# Altium schematic sheet Top Level.SchDoc
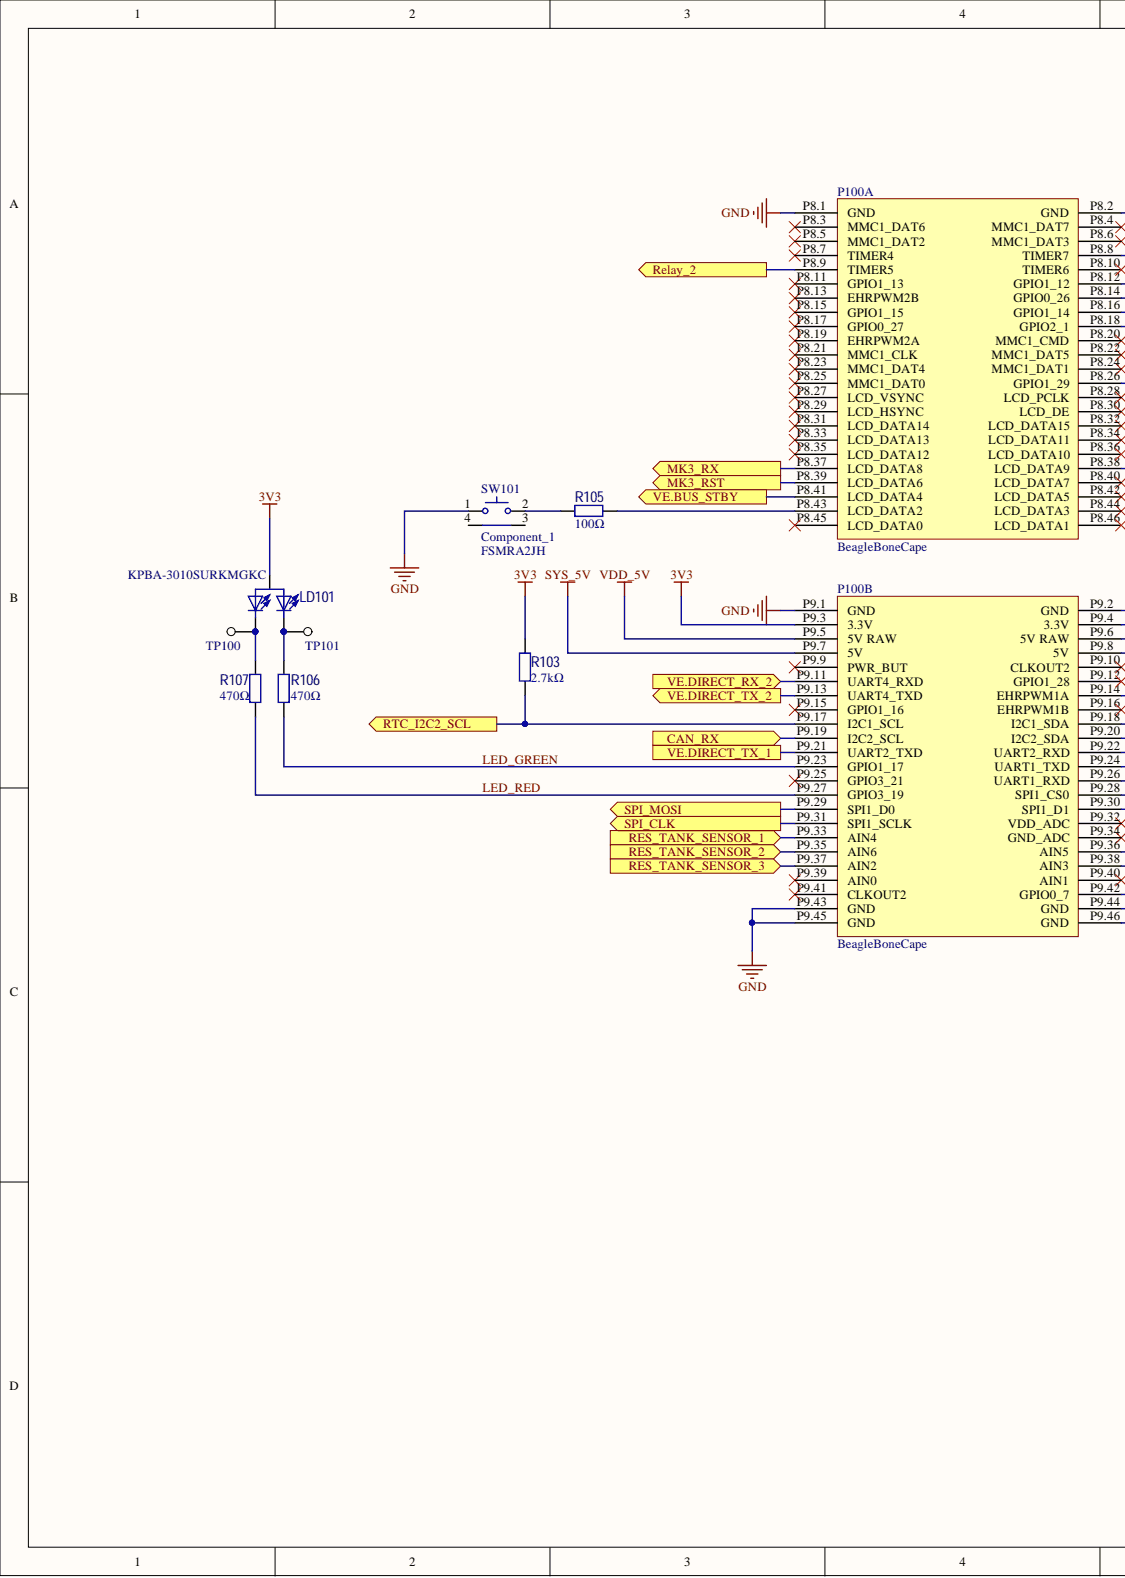
[diagram p0: Top Level.SchDoc, left]
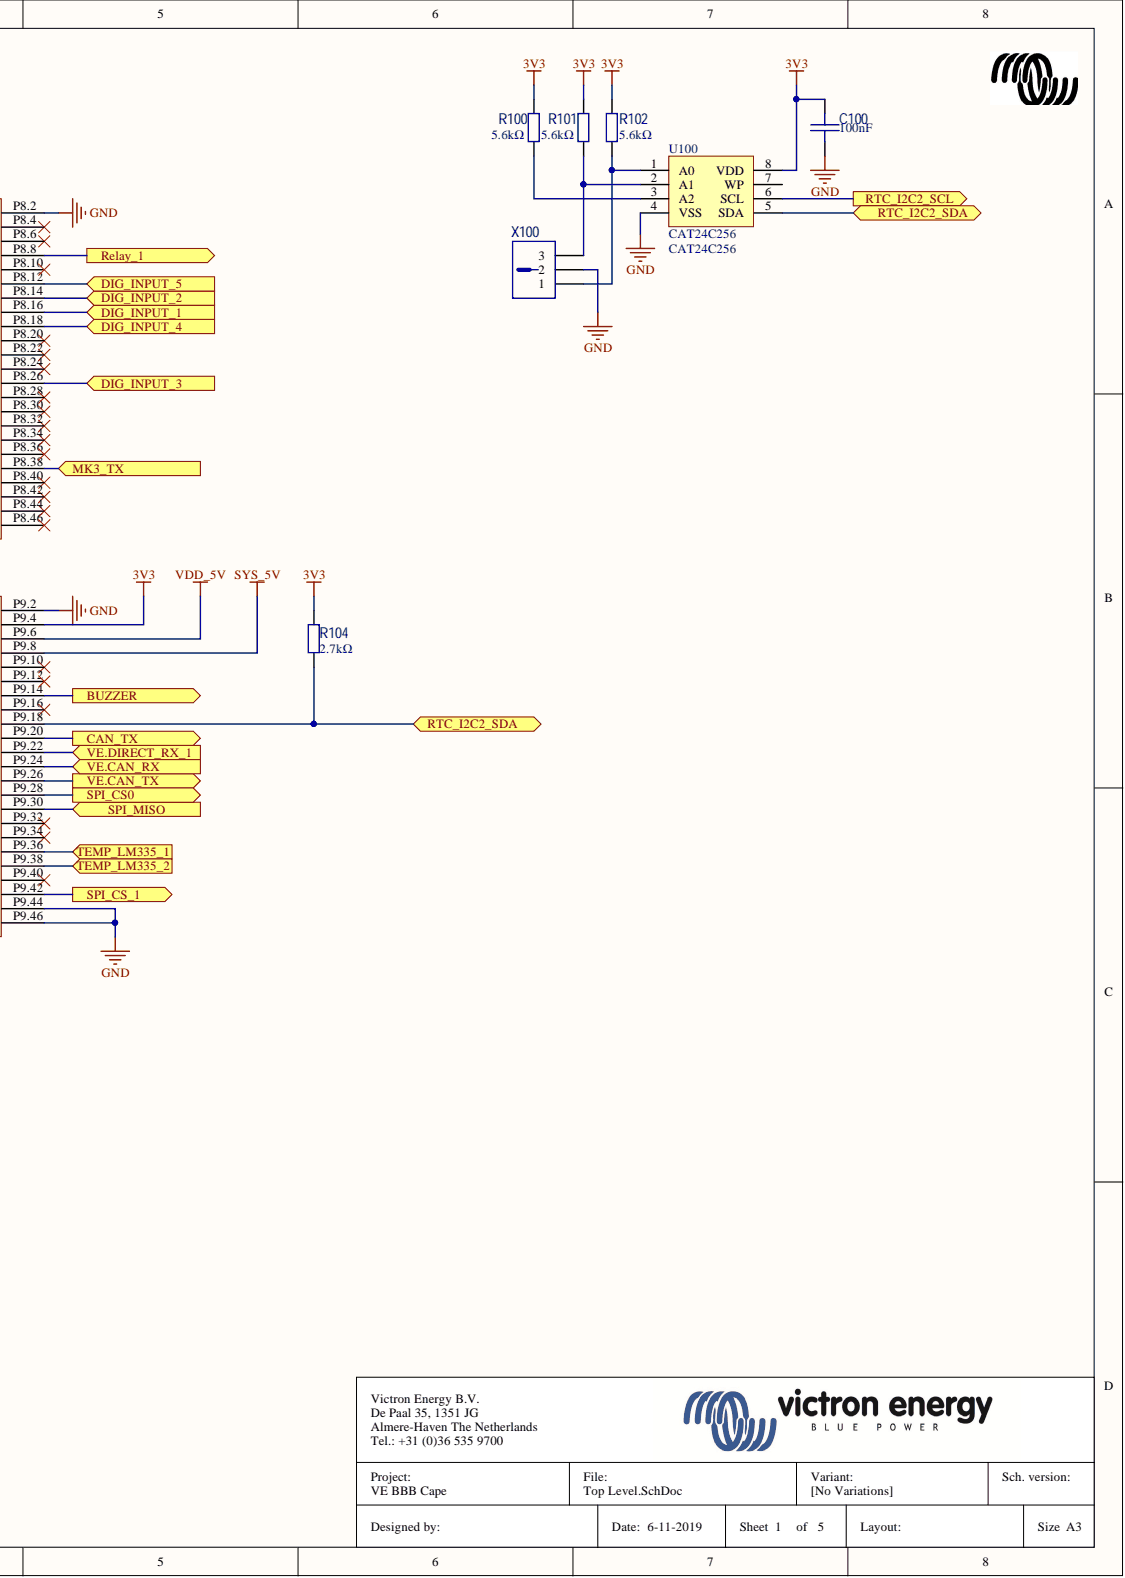
[diagram p1: Top Level.SchDoc, right]

## Parts
C100  value 100nF  [C0805_R]  (hidden comment: 1035)
LD101  value KPBA-3010SURKMGKC  MAN_PARTNUMBER: KPBA-3010SURKMGKC  [SMD side LED 2color KPBA]  (hidden comment: 101623)
P100  BeagleBoneCape  [BeagleBoneCape]  (parts A,B)
R100  value 5.6kΩ  [R0805_R]  (hidden comment: 390)
R101  value 5.6kΩ  [R0805_R]  (hidden comment: 390)
R102  value 5.6kΩ  [R0805_R]  (hidden comment: 390)
R103  value 2.7kΩ  [R0805_R]  (hidden comment: 382)
R104  value 2.7kΩ  [R0805_R]  (hidden comment: 382)
R105  value 100Ω  [R0805_R]  (hidden comment: 348)
R106  value 470Ω  [R0805_R]  (hidden comment: 364)
R107  value 470Ω  [R0805_R]  (hidden comment: 364)
SW101  Component_1  value FSMRA2JH  [FSMRA2JH]
TP100  CN1  [testpad_round_1mm]  (value not drawn)  (no BOM)
TP101  CN1  [testpad_round_1mm]  (value not drawn)  (no BOM)
U100  CAT24C256  [SOIC-8]
X100  100129  [3M]  (value not drawn)

## Ports
BUZZER, CAN_RX, CAN_TX, DIG_INPUT_1, DIG_INPUT_2, DIG_INPUT_3, DIG_INPUT_4, DIG_INPUT_5, MK3_RST, MK3_RX, MK3_TX, Relay_1, Relay_2, RES_TANK_SENSOR_1, RES_TANK_SENSOR_2, RES_TANK_SENSOR_3, RTC_I2C2_SCL, RTC_I2C2_SDA, SPI_CLK, SPI_CS0, SPI_CS_1, SPI_MISO, SPI_MOSI, TEMP_LM335_1, TEMP_LM335_2, VE.BUS_STBY, VE.CAN_RX, VE.CAN_TX, VE.DIRECT_RX_1, VE.DIRECT_RX_2, VE.DIRECT_TX_1, VE.DIRECT_TX_2

## Nets
3V3: C100.1 LD101.1 P100.P9.3(3.3V) P100.P9.4(3.3V) R100.1 R101.1 R102.1 R103.1 R104.1 U100.8(VDD)
GND: C100.2 P100.P8.1(GND) P100.P8.2(GND) P100.P9.1(GND) P100.P9.2(GND) P100.P9.43(GND) P100.P9.44(GND) P100.P9.45(GND) P100.P9.46(GND) SW101.1 U100.4(VSS) X100.2
SYS_5V: P100.P9.7(5V) P100.P9.8(5V)
VDD_5V: P100.P9.5(5V_RAW) P100.P9.6(5V_RAW)
BUZZER: P100.P9.14(EHRPWM1A) ; port BUZZER
CAN_RX: P100.P9.19(I2C2_SCL) ; port CAN_RX
CAN_TX: P100.P9.20(I2C2_SDA) ; port CAN_TX
DIG_INPUT_1: P100.P8.16(GPIO1_14) ; port DIG_INPUT_1
DIG_INPUT_2: P100.P8.14(GPIO0_26) ; port DIG_INPUT_2
DIG_INPUT_3: P100.P8.26(GPIO1_29) ; port DIG_INPUT_3
DIG_INPUT_4: P100.P8.18(GPIO2_1) ; port DIG_INPUT_4
DIG_INPUT_5: P100.P8.12(GPIO1_12) ; port DIG_INPUT_5
LED_GREEN: P100.P9.23(GPIO1_17) R106.2
LED_RED: P100.P9.27(GPIO3_19) R107.2
MK3_RST: P100.P8.39(LCD_DATA6) ; port MK3_RST
MK3_RX: P100.P8.37(LCD_DATA8) ; port MK3_RX
MK3_TX: P100.P8.38(LCD_DATA9) ; port MK3_TX
Relay_1: P100.P8.8(TIMER7) ; port Relay_1
Relay_2: P100.P8.9(TIMER5) ; port Relay_2
RES_TANK_SENSOR_1: P100.P9.33(AIN4) ; port RES_TANK_SENSOR_1
RES_TANK_SENSOR_2: P100.P9.35(AIN6) ; port RES_TANK_SENSOR_2
RES_TANK_SENSOR_3: P100.P9.37(AIN2) ; port RES_TANK_SENSOR_3
RTC_I2C2_SCL: P100.P9.17(I2C1_SCL) R103.2 U100.6(SCL) ; port RTC_I2C2_SCL
RTC_I2C2_SDA: P100.P9.18(I2C1_SDA) R104.2 U100.5(SDA) ; port RTC_I2C2_SDA
SPI_CLK: P100.P9.31(SPI1_SCLK) ; port SPI_CLK
SPI_CS0: P100.P9.28(SPI1_CS0) ; port SPI_CS0
SPI_CS_1: P100.P9.42(GPIO0_7) ; port SPI_CS_1
SPI_MISO: P100.P9.30(SPI1_D1) ; port SPI_MISO
SPI_MOSI: P100.P9.29(SPI1_D0) ; port SPI_MOSI
TEMP_LM335_1: P100.P9.36(AIN5) ; port TEMP_LM335_1
TEMP_LM335_2: P100.P9.38(AIN3) ; port TEMP_LM335_2
VE.BUS_STBY: P100.P8.41(LCD_DATA4) ; port VE.BUS_STBY
VE.CAN_RX: P100.P9.24(UART1_TXD) ; port VE.CAN_RX
VE.CAN_TX: P100.P9.26(UART1_RXD) ; port VE.CAN_TX
VE.DIRECT_RX_1: P100.P9.22(UART2_RXD) ; port VE.DIRECT_RX_1
VE.DIRECT_RX_2: P100.P9.11(UART4_RXD) ; port VE.DIRECT_RX_2
VE.DIRECT_TX_1: P100.P9.21(UART2_TXD) ; port VE.DIRECT_TX_1
VE.DIRECT_TX_2: P100.P9.13(UART4_TXD) ; port VE.DIRECT_TX_2
NetLD101_2: LD101.2 R107.1 TP100.1
NetLD101_3: LD101.3 R106.1 TP101.1
NetP100_P8.3: P100.P8.3(MMC1_DAT6) P100.P8.30(LCD_DE)
NetP100_P8.4: P100.P8.4(MMC1_DAT7) P100.P8.40(LCD_DATA7)
NetP100_P8.43: P100.P8.43(LCD_DATA2) R105.2
NetR100_2: R100.2 U100.3(A2)
NetR101_2: R101.2 U100.2(A1) X100.3
NetR102_2: R102.2 U100.1(A0) X100.1
NetR105_1: R105.1 SW101.2

Not connected: P100 P8.5(MMC1_DAT2) P8.6(MMC1_DAT3) P8.7(TIMER4) P8.10(TIMER6) P8.11(GPIO1_13) P8.13(EHRPWM2B) P8.15(GPIO1_15) P8.17(GPIO0_27) P8.19(EHRPWM2A) P8.20(MMC1_CMD) P8.21(MMC1_CLK) P8.22(MMC1_DAT5) P8.23(MMC1_DAT4) P8.24(MMC1_DAT1) P8.25(MMC1_DAT0) P8.27(LCD_VSYNC) P8.28(LCD_PCLK) P8.29(LCD_HSYNC) P8.31(LCD_DATA14) P8.32(LCD_DATA15) P8.33(LCD_DATA13) P8.34(LCD_DATA11) P8.35(LCD_DATA12) P8.36(LCD_DATA10) P8.42(LCD_DATA5) P8.44(LCD_DATA3) P8.45(LCD_DATA0) P8.46(LCD_DATA1) P9.9(PWR_BUT) P9.10(CLKOUT2) P9.12(GPIO1_28) P9.15(GPIO1_16) P9.16(EHRPWM1B) P9.25(GPIO3_21) P9.32(VDD_ADC) P9.34(GND_ADC) P9.39(AIN0) P9.40(AIN1) P9.41(CLKOUT2); SW101 3 4; U100 7(WP)

## Library data of the parts
C100 = 1035: Cap, SMD 0805, ceramic, X7R, 100nF, 50V, 10%, Yageo; INDIC_PARTNO: CSE100NF0805YAGY; MAN_PARTNUMBER: CC0805KPX7R9BB104; Database Table Name: AllComponents; INDIC_PRICE: 0,068; Shape: 805; Tol: 10,00%; Cls: CAP; Component Kind: Standard; MANUFACTURER: Yageo; Part: 1035; PartID: 1035; Supplier 1: Mouser; Supplier Part Number 1: 603-CC805KPX7R9BB104; Supplier Price 1: 0,005; Voltage: 50V; Library Name: VictronAltiumComponents.SVNDBLib
LD101 = 101623: KingBright Red/Green side SMD LED; Cls: DIO; Database Table Name: Diode; Shape: SideLed; Component Kind: Standard; MANUFACTURER: Kingbright; Part: 101623; PartID: 101623; Supplier 1: Farnell; Supplier Part Number 1: 2464016; Supplier Price 1: 0,12; Library Name: VictronAltiumComponents.SVNDBLib
P100 = 101783: Beagle Bone cape footrpint; Cls: MOD; Database Table Name: AllComponents; Component Kind: Standard; Part: 101783; PartID: 101783; Library Name: VictronAltiumComponents.SVNDBLib
R100-R102 = 390: INCAP_PARTNO: 1035031286; INDIC_PARTNO: RSCE5K6F0805YAGY; MAN_PARTNUMBER: RC0805FR-075K6L; MANUFACTURER: YAGEO; Part_Description: RES,MF,5K6 0.125W 1%0805; Cls: RES; Database Table Name: COMPDATA$; INCAP_PRICE: 0.0014; INDIC_PRICE: 0.0022; Power: 0,125W; Shape: 805; Tol: 0.01; VENDOR: Mouser; VENDOR_PRICE: 0.003; VENDOR_STOCK_NR: 603-RC0805FR-075K6L; Component Kind: Standard; ComponentLink1Description: \datasheets\Yageo-RC0805FR-0710KL-datasheet.pdf; Part: 390; Library Name: Data Base Librarys.DbLib
R103 R104 = 382: INCAP_PARTNO: 1035031279; MAN_PARTNUMBER: RC0805FR-072K7L; MANUFACTURER: YAGEO; Part_Description: RES,MF,2K7 0.125W 1%0805; Cls: RES; Database Table Name: COMPDATA$; INCAP_PRICE: 0.0014; Power: 0,125W; Shape: 805; Tol: 0.01; Component Kind: Standard; ComponentLink1Description: \datasheets\Yageo-RC0805FR-0710KL-datasheet.pdf; Part: 382; Library Name: Data Base Librarys.DbLib
R105 = 348: Res, SMD 0805, MF, 100E, 0.125W 1% 0805; INDIC_PARTNO: RSCE00100RFB0YAY; MAN_PARTNUMBER: RC0805FR-07100RL; MANUFACTURER: YAGEO; Database Table Name: Resistor; INCAP_PRICE: 0,0009; INDIC_PRICE: 0,002; Power: 0,125W; Shape: 0805; Tol: 1,00%; Cls: RES; Component Kind: Standard; ComponentLink1Description: \datasheets\Yageo-RC0805FR-0710KL-datasheet.pdf; Part: 348; PartID: 348; Supplier 1: Mouser; Supplier Part Number 1: 603-RC0805FR-07100RL; Supplier Price 1: 0,003; Library Name: VictronAltiumComponents.SVNDBLib
R106 R107 = 364: Res, SMD 0805, MF, 470E, 0.125W 1% 0805; INDIC_PARTNO: RSCE00470RFB7YAY; MAN_PARTNUMBER: RC0805FR-07470RL; MANUFACTURER: YAGEO; Database Table Name: Resistor; INCAP_PRICE: 0,0009; INDIC_PRICE: 0,0008; Power: 0,125W; Shape: 0805; Tol: 1,00%; Cls: RES; Component Kind: Standard; ComponentLink1Description: \datasheets\Yageo-RC0805FR-0710KL-datasheet.pdf; Part: 364; PartID: 364; Library Name: VictronAltiumComponents.SVNDBLib
SW101 = 101616: Angled tactile button short; MAN_PARTNUMBER: 1825027-5; MANUFACTURER: TE; Cls: SW; Database Table Name: Mechanical; Component Kind: Standard; Part: 101616; PartID: 101616; Supplier 1: Digikey; Supplier Part Number 1: 450-1662-ND; Supplier Price 1: 0,09428; Library Name: VictronAltiumComponents.SVNDBLib
TP100 TP101 = 100320: Testpad, Round, D=1mm; Cls: CON; Database Table Name: Connector; Part: 100320; PartID: 100320; Library Name: VictronAltiumComponents.SVNDBLib
U100 = 101557: IC, Serial EEProm 256; MAN_PARTNUMBER: CAT24C256WI-GT3; MANUFACTURER: On-semi; Database Table Name: AllComponents; Shape: SOIC8; VENDOR: Digikey; VENDOR_PRICE: 0,23173; VENDOR_STOCK_NR: CAT24C256WI-GT3OSTR-ND; Cls: IC; Component Kind: Standard; Part: 101557; PartID: 101557; Supplier 1: Digikey; Supplier Part Number 1: CAT24C256WI-GT3OSCT-ND; Supplier Price 1: 0,26163; Value: CAT24C256; Library Name: VictronAltiumComponents.SVNDBLib
X100 = 100129: MAN_PARTNUMBER: 4-103321-5; MANUFACTURER: TE connectivity; Part_Description: Connector 3pins pitch 2,54mm header male; Cls: CON; Database Table Name: COMPDATA$; VENDOR: Mouser; VENDOR_PRICE: 0.09; VENDOR_STOCK_NR: 571-4-103321-5; Component Kind: Standard; Part: 100129; Library Name: Data Base Librarys.DbLib
